# Altium schematic sheet TPVSI_Capacitor_Voltage_Sensing.SchDoc(TPVSI_Capacitor_Voltage_Sensing)
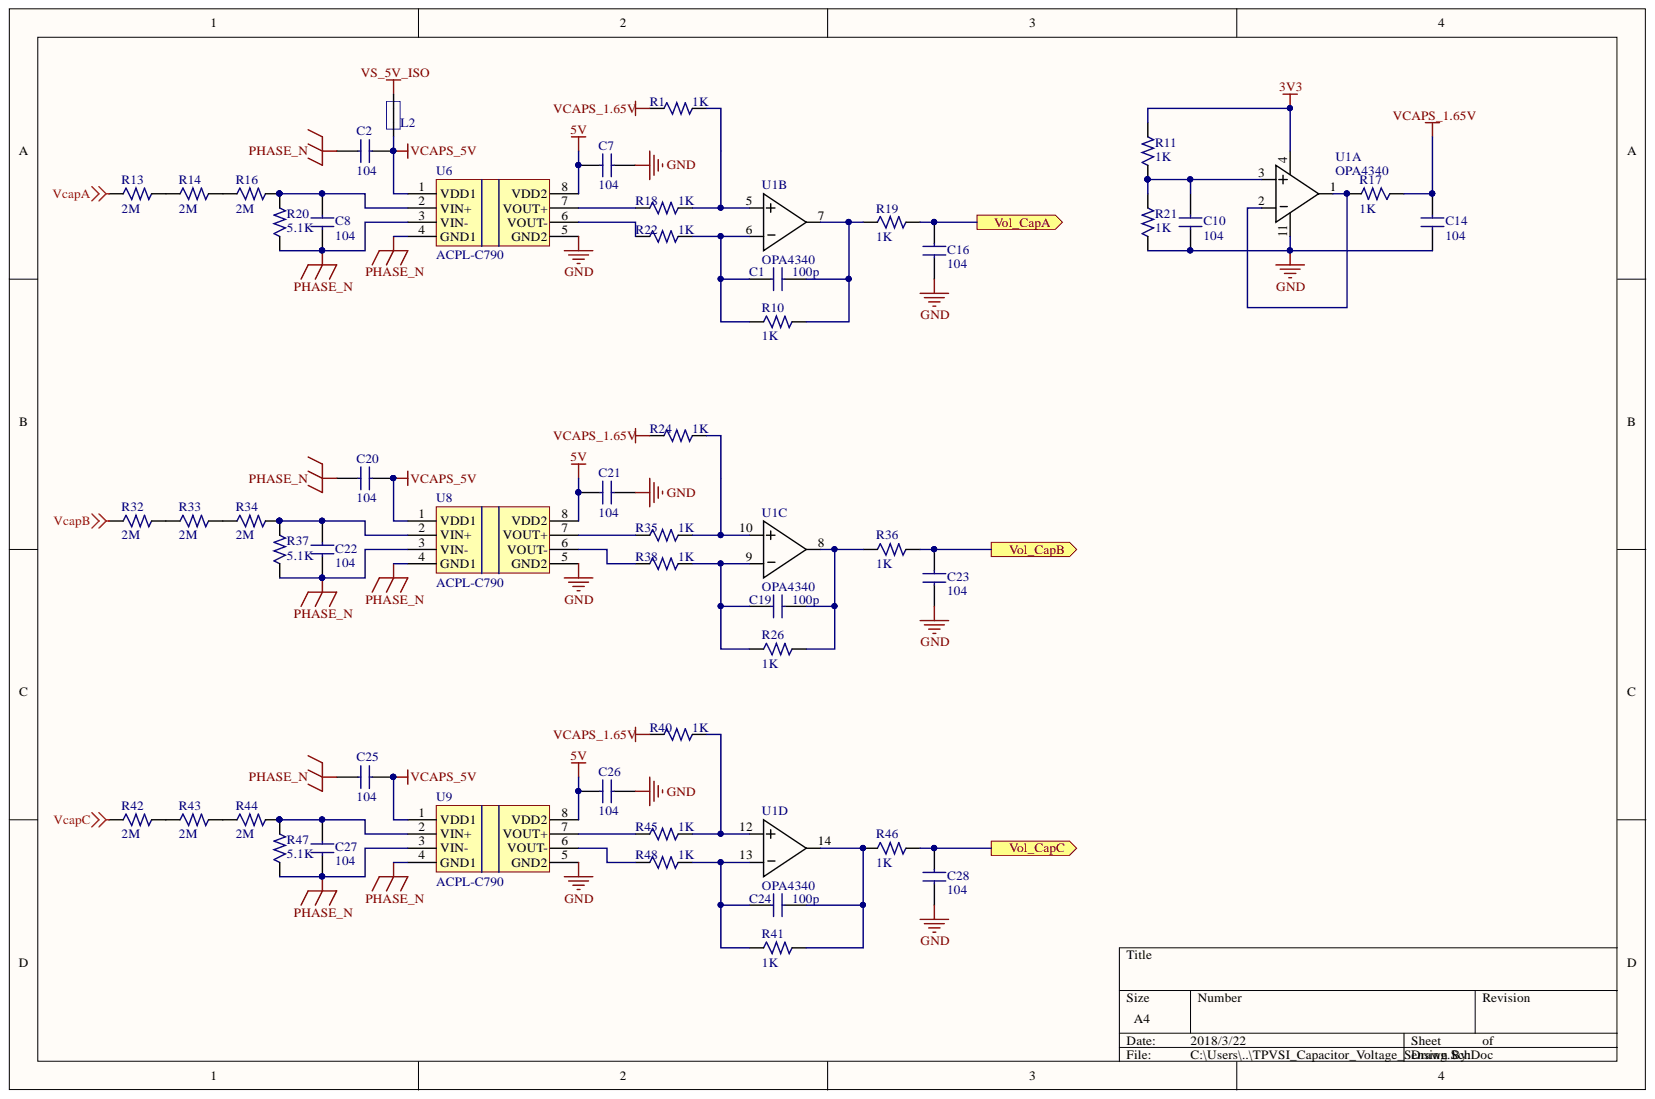
[diagram p0: TPVSI_Capacitor_Voltage_Sensing.SchDoc]

## Parts
C1  100p  [C-RAD-0603]
C2  104  [C-RAD-0603]
C7  104  [C-RAD-0603]
C8  104  [C-RAD-0603]
C10  104  [C-RAD-0603]
C14  104  [C-RAD-0603]
C16  104  [C-RAD-0603]
C19  100p  [C-RAD-0603]
C20  104  [C-RAD-0603]
C21  104  [C-RAD-0603]
C22  104  [C-RAD-0603]
C23  104  [C-RAD-0603]
C24  100p  [C-RAD-0603]
C25  104  [C-RAD-0603]
C26  104  [C-RAD-0603]
C27  104  [C-RAD-0603]
C28  104  [C-RAD-0603]
L2  Inductor_Small  [1206]  (value not drawn)
R1  1K  [0603_1]
R10  1K  [0603_1]
R11  1K  [0603_1]
R13  2M  [2512]
R14  2M  [2512]
R16  2M  [2512]
R17  1K  [0603_1]
R18  1K  [0603_1]
R19  1K  [0603_1]
R20  5.1K  [0603_1]
R21  1K  [0603_1]
R22  1K  [0603_1]
R24  1K  [0603_1]
R26  1K  [0603_1]
R32  2M  [2512]
R33  2M  [2512]
R34  2M  [2512]
R35  1K  [0603_1]
R36  1K  [0603_1]
R37  5.1K  [0603_1]
R38  1K  [0603_1]
R40  1K  [0603_1]
R41  1K  [0603_1]
R42  2M  [2512]
R43  2M  [2512]
R44  2M  [2512]
R45  1K  [0603_1]
R46  1K  [0603_1]
R47  5.1K  [0603_1]
R48  1K  [0603_1]
U1  OPA4340  [SOP-14N]  (parts A,B,C,D)
U6  ACPL-C790  [SSO8]
U8  ACPL-C790  [SSO8]
U9  ACPL-C790  [SSO8]

## Ports
Vol_CapA, Vol_CapB, Vol_CapC

## Nets
3V3: R11.2 U1.4
5V: C7.1 C21.1 C26.1 U6.8(VDD2) U8.8(VDD2) U9.8(VDD2)
GND: C7.2 C10.1 C14.1 C16.1 C21.2 C23.1 C26.2 C28.1 R21.1 U1.11 U6.5(GND2) U8.5(GND2) U9.5(GND2)
PHASE_N: C2.1 C8.1 C20.1 C22.1 C25.1 C27.1 R20.1 R37.1 R47.1 U6.3(VIN-) U6.4(GND1) U8.3(VIN-) U8.4(GND1) U9.3(VIN-) U9.4(GND1)
VcapA: R13.1
VcapB: R32.1
VcapC: R42.1
VCAPS_1.65V: C14.2 R1.1 R17.1 R24.1 R40.1
VCAPS_5V: C2.2 C20.2 C25.2 L2.1 U6.1(VDD1) U8.1(VDD1) U9.1(VDD1)
VS_5V_ISO: L2.2
Vol_CapA: C16.2 R19.2 ; port Vol_CapA
Vol_CapB: C23.2 R36.2 ; port Vol_CapB
Vol_CapC: C28.2 R46.2 ; port Vol_CapC
NetC1_1: C1.1 R10.1 R22.2 U1.6
NetC1_2: C1.2 R10.2 R19.1 U1.7
NetC8_2: C8.2 R16.2 R20.2 U6.2(VIN+)
NetC10_2: C10.2 R11.1 R21.2 U1.3
NetC19_1: C19.1 R26.1 R38.2 U1.9
NetC19_2: C19.2 R26.2 R36.1 U1.8
NetC22_2: C22.2 R34.2 R37.2 U8.2(VIN+)
NetC24_1: C24.1 R41.1 R48.2 U1.13
NetC24_2: C24.2 R41.2 R46.1 U1.14
NetC27_2: C27.2 R44.2 R47.2 U9.2(VIN+)
NetR1_2: R1.2 R18.2 U1.5
NetR13_2: R13.2 R14.1
NetR14_2: R14.2 R16.1
NetR17_2: R17.2 U1.1 U1.2
NetR18_1: R18.1 U6.7(VOUT+)
NetR22_1: R22.1 U6.6(VOUT-)
NetR24_2: R24.2 R35.2 U1.10
NetR32_2: R32.2 R33.1
NetR33_2: R33.2 R34.1
NetR35_1: R35.1 U8.7(VOUT+)
NetR38_1: R38.1 U8.6(VOUT-)
NetR40_2: R40.2 R45.2 U1.12
NetR42_2: R42.2 R43.1
NetR43_2: R43.2 R44.1
NetR45_1: R45.1 U9.7(VOUT+)
NetR48_1: R48.1 U9.6(VOUT-)

## Library data of the parts
C1 C2 C7 C8 C10 C14 C16 C19-C28 = cap: Component Kind: Standard; Library Name: Chu_16.IntLib
R1 R10 R11 R13 R14 R16-R22 R24 R26 R32-R38 R40-R48 = Res: Component Kind: Standard; Library Name: Chu_16.IntLib
L2 = Inductor_Small: Component Kind: Standard; Library Name: Chu_16.IntLib
U1 = OPA4340: Component Kind: Standard; Library Name: Chu_16.IntLib
U6 U8 U9 = ACPL-C790: Component Kind: Standard; Library Name: Chu_16.IntLib
